# Altium schematic sheet Energy Harvesting.SchDoc("Energy Harvesting")
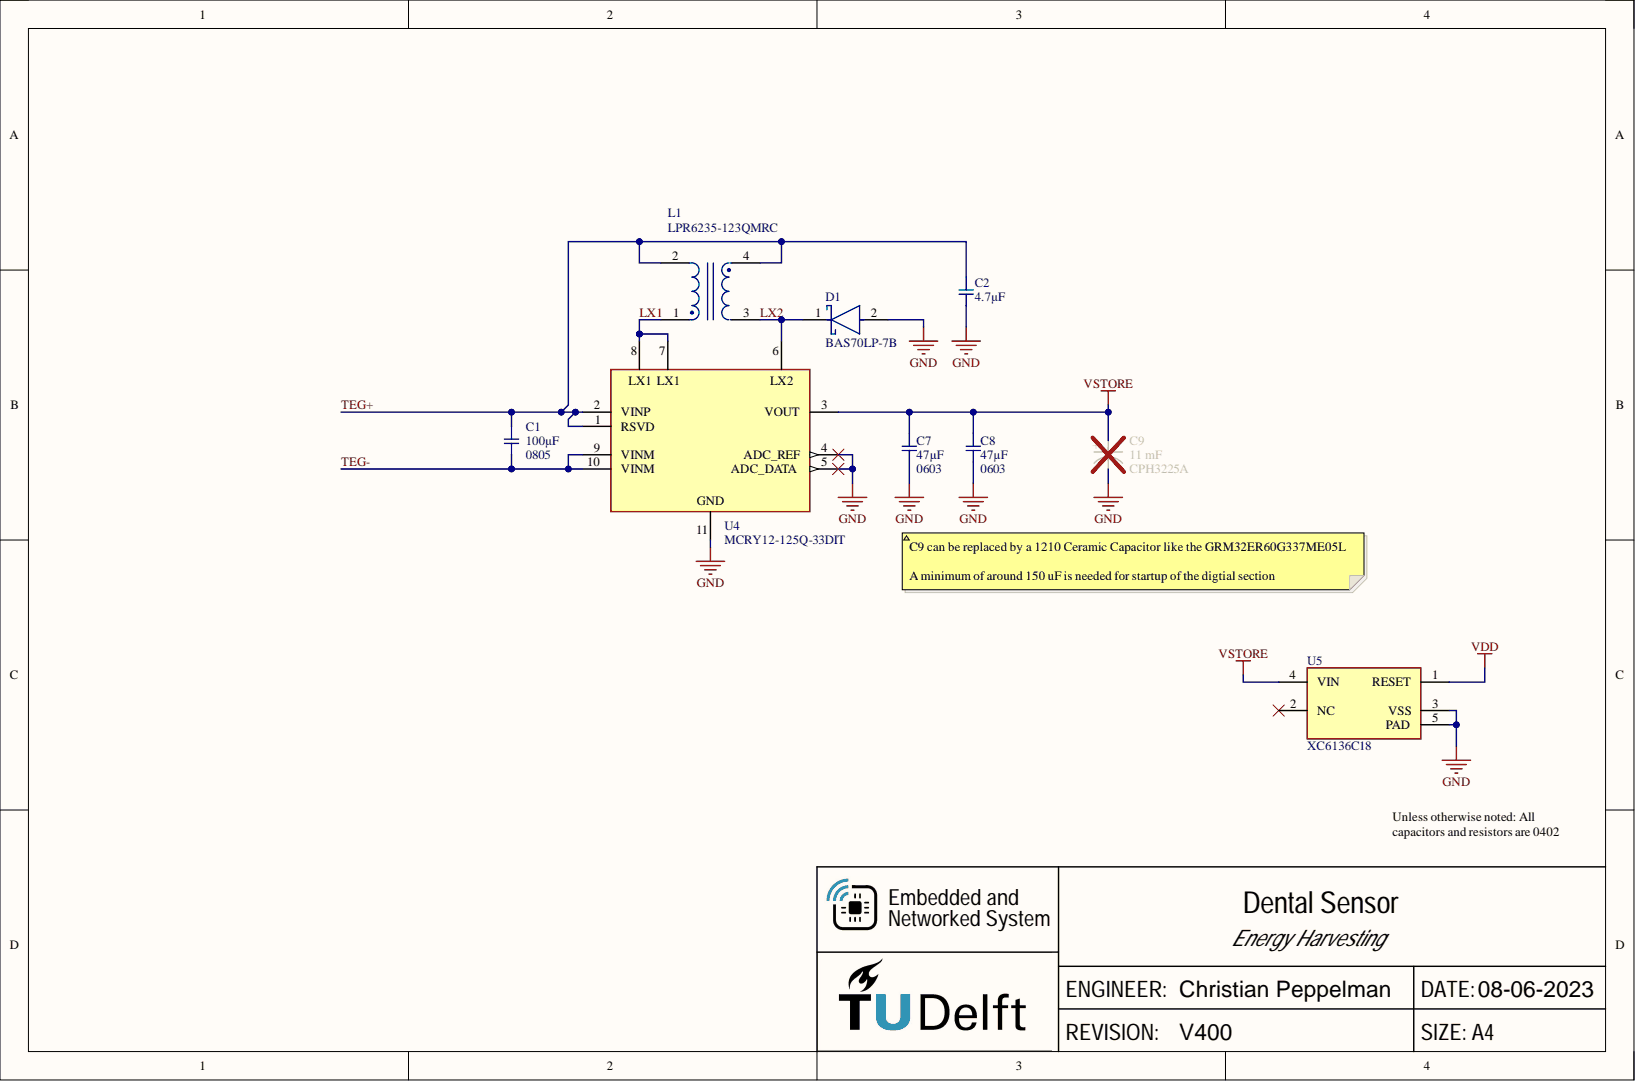
[diagram p0: Energy Harvesting.SchDoc]

## Parts
C1  100µF  Package / Case: 0805  [CAPC2012X12N]
C2  4.7µF  [RESC1005X03N]
C7  47µF  Package / Case: 0603  [CAPC1608X12N]
C8  47µF  Package / Case: 0603  [CAPC1608X12N]
C9  CPH3225A  Capacity: 11 mF  [CPH3225A]
D1  BAS70LP-7B  [FP-X1-DFN1006-2-MFG]
L1  LPR6235-123QMRC  [LPR6235]
U4  MCRY12-125Q-33DIT  [10DFN]
U5  XC6136C18  [USPQ-4B05]

## Nets
GND: C2.2 C7.2 C8.2 C9.2 D1.2 U4.4(ADC_REF) U4.5(ADC_DATA) U4.11 U5.3(VSS) U5.5(PAD)
VDD: U5.1(RESET)
VSTORE: C7.1 C8.1 C9.1 U4.3(VOUT) U5.4(VIN)
LX1: L1.1 U4.7 U4.8
LX2: D1.1 L1.3 U4.6
TEG+: C1.1 C2.1 L1.2 L1.4 U4.1(RSVD) U4.2(VINP)
TEG-: C1.2 U4.9(VINM) U4.10(VINM)

Not connected: U5 2(NC)

## Library data of the parts
C1 = GRM21BC80E107ME15L: CAP CER 100UF 2.5V X6S 0805; Manufacturer: Murata Electronics North America; @ qty: 0; Minimum Quantity: 1; Tolerance: ±20%; Unit Price (USD): 2.17; Applications: General Purpose; Component Kind: Standard; Datasheets: http://search.murata.co.jp/Ceramy/image/img/A01X/G101/ENG/GRM21BC80E107ME15-01.pdf; Digi-Key Part Number: 490-13977-1-ND; Factory Stock: 0; Features: -; Height - Seated (Max): -; Image: //media.digikey.com/Renders/Murata%20Renders/0805%20(2012%20Metric)%20.85mm.jpg; Lead Spacing: -; Lead Style: -; Library Name: capacitor_murata_0805.IntLib; Mounting Type: Surface Mount, MLCC; Operating Temperature: -55°C ~ 105°C; Packaging: Cut Tape (CT); Part Number: GRM21BC80E107ME15L; Part Status: Active; Quantity Available: 5990; Ratings: -; Series: GRM; Size / Dimension: 0.079" L x 0.049" W (2.00mm x 1.25mm); Temperature Coefficient: X6S; Thickness (Max): 0.057" (1.45mm); Value: 100µF; Voltage: 2.5V
C2 = GRM155R60J475ME87D: CAP CER 4.7UF 6.3V X5R 0402; Manufacturer: Murata Electronics North America; @ qty: 0; Minimum Quantity: 1; Tolerance: ±20%; Unit Price (USD): 0.14; Applications: General Purpose; Component Kind: Standard; Datasheets: http://www.murata.com/~/media/webrenewal/support/library/catalog/products/capacitor/mlcc/c02e.pdf; Digi-Key Part Number: 490-5408-1-ND; Factory Stock: 0; Features: Derating Recommended; Height - Seated (Max): -; Image: //media.digikey.com/Renders/Murata%20Renders/ZRB-SERIES-0402.jpg; Lead Spacing: -; Lead Style: -; Library Name: capacitor_murata_0402.IntLib; Mounting Type: Surface Mount, MLCC; Operating Temperature: -55°C ~ 85°C; Package / Case: 0402 (1005 Metric); Packaging: Cut Tape (CT); Part Number: GRM155R60J475ME87D; Part Status: Active; Quantity Available: 226483; Ratings: -; Series: GRM; Size / Dimension: 0.039" L x 0.020" W (1.00mm x 0.50mm); Temperature Coefficient: X5R; Thickness (Max): 0.022" (0.55mm); Value: 4.7µF; Voltage: 6.3V
C7 C8 = GRJ155R60J106ME11D: CAP CER 47UF 6.3V X5R 0603; Manufacturer: Murata Electronics North America; @ qty: 0; Minimum Quantity: 1; Tolerance: ±20%; Unit Price (USD): 0.23; Applications: Boardflex Sensitive; Component Kind: Standard; Datasheets: http://psearch.en.murata.com/capacitor/product/GRJ155R60J106ME11%23.pdf; Digi-Key Part Number: 490-13211-1-ND; Factory Stock: 0; Features: Derating Recommended, Soft Termination; Height - Seated (Max): -; Image: //media.digikey.com/Renders/Murata%20Renders/ZRB-SERIES-0402.jpg; Lead Spacing: -; Lead Style: -; Library Name: capacitor_murata_0402.IntLib; Mounting Type: Surface Mount, MLCC; Operating Temperature: -55°C ~ 85°C; Packaging: Cut Tape (CT); Part Number: GRJ155R60J106ME11D; Part Status: Active; Quantity Available: 64064; Ratings: -; Series: GRJ; Size / Dimension: 0.039" L x 0.020" W (1.00mm x 0.50mm); Temperature Coefficient: X5R; Thickness (Max): 0.028" (0.70mm); Value: 47µF; Voltage: 6.3V
C9 = CPH3225A: Supercapacitors / Ultracapacitors Reflow EDLC 3.3V, 11mF, 160 Ohm; Component Kind: Standard; Library Name: Library.SchLib
D1 = CMP-12790-000002-1: DIODE SCHTTKY 70V 70MA X1DFN1006; Manufacturer: Diodes Inc.; Capacitance: 1pF; Max Junction Temp: +150°C; Max Surge Forward Current: 800mA; Peak Reverse Current: 0.1uA; Standoff Height: 0mm; Value: 1pF; Automotive: No; Category: Diode; Component Kind: Standard; ComponentLink2Description: Datasheet; ComponentLink2URL: https://www.diodes.com/assets/Datasheets/BAS70LP.pdf; Device Class L1: Discrete Semiconductors; Device Class L2: Diodes; Device Class L3: Schottky Diodes; Digikey Description: DIODE SCHTTKY 70V 70MA X1DFN1006; Footprint Url: https://www.diodes.com/assets/Package-Files/X1-DFN1006-2.pdf; Forward Current: 70mA; Height: 0.53mm; Lead Free: Yes; Library Name: Altium Content Vault; Manufacturer Part Number: BAS70LP-7B; Max Repetitive Reverse Voltage: 70V; Mouser Description: Schottky Diodes & Rectifiers Schottky Diode; Octopart: https://octopart.com/bas70lp-7b-diodes+inc.-22053921; Package: X1-DFN1006-2; Reverse Voltage: 70V; RoHS: TRUE; Temperature: -65°C to +150°C; Temperature Range High: +150°C; Temperature Range Low: -65°C
L1 = LPR6235-123QMRC: Gekoppelde smoorspoelen 12.5uH 12% 900mA 200 Ohms; Component Kind: Standard; Library Name: Library.SchLib
U4 = MCRY12: Matrix Mercury Energy Harvesting Synchronous Boost Converter with Microwatt Cold-Start, Input Impedance Matching and Regulated Output; Component Kind: Standard; Library Name: Library.SchLib
U5 = XC6136N18A9R-G: Controlecircuits Ultra-Low Power (88nA) Voltage Detector; Component Kind: Standard; Library Name: Library.SchLib
